# Altium schematic sheet Sheet1.SchDoc
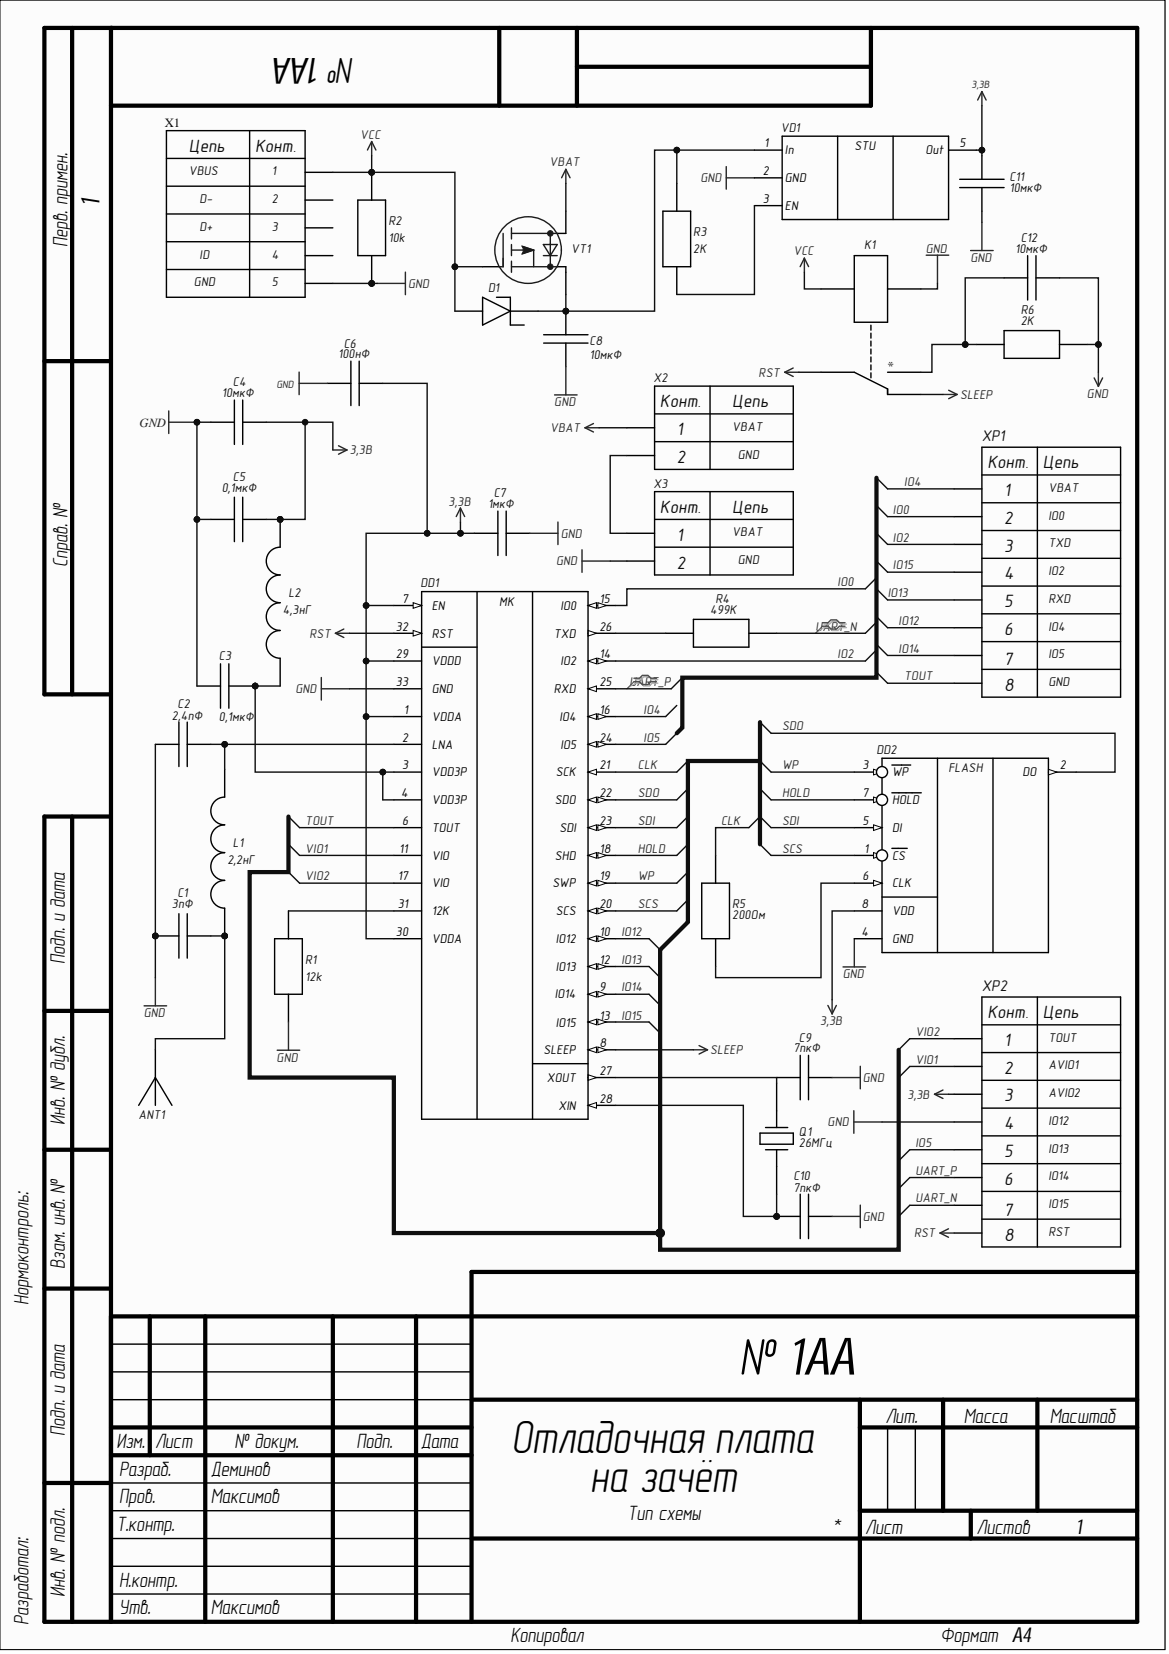
[diagram p0: Sheet1.SchDoc]

## Parts
*  ANT1
C1  3пФ  [0201 C0G 7пФ 25В]
C4  10мкФ  [FB 0603]
C5  0,1мкФ  [RES 0402]
C6  100нФ  [RES 0402]
C8  10мкФ  [FB 0603]
C9  7пкФ  [0201 C0G 7пФ 25В]
C10  7пкФ  [0201 C0G 7пФ 25В]
D1  (no value)  [MBR120]
DD1  esp8266ex  [ESP8266]  (value not drawn)
DD2  M25P16-VMN6TP  [SOIC-8(3.90мм)]  (value not drawn)
K1  gost relay  [RELE]  (value not drawn)
L1  2,2нГ  [FB 0603]
L2  4,3нГ  [RES 0402]
Q1  26МГц  [SMD02520C4]
R1  12k  [RES 0805]
R2  10k  [RES 0805]
R3  2K  [RES 0805]
R4  499К  [RES 0402]
R5  200Ом  [RES 0805]
R6  2K  [RES 0805]
VD1  ap2112  [2112]  (value not drawn)
VT1  (no value)  [SOT23-3]
X1  usb_micro  [USB_mini_B]  (value not drawn)
X2  battery_x2  [Battery_x2AA]  (value not drawn)
X3  battery_x2  [Battery_x2AA]  (value not drawn)
XP1  PLD-8  [PLD-8]  (value not drawn)
XP2  PLD-8  [PLD-8]  (value not drawn)
С2  2,4пФ  [0201 C0G 7пФ 25В]
С3  0,1мкФ  [RES 0402]
С7  1мкФ  [FB 0603]
С11  10мкФ  [FB 0603]
С12  10мкФ  [FB 0603]

## Nets
3,3B: C4.2 C5.1 C6.1 DD1.1(VDDA) DD1.7(EN) DD1.29(VDDD) DD1.30(VDDA) DD2.8(VDD) L2.2 VD1.5(Out) XP2.3 С7.1 С11.2
GND: C1.2 C4.1 C5.2 C6.2 C8.1 C9.1 C10.1 DD1.33(GND) DD2.4(GND) K1.8 R1.2 R2.2 R6.1 VD1.2(GND) X1.5 X3.2 XP2.4 С2.2 С3.2 С7.2 С11.1 С12.1
RST: DD1.32(RST) K1.3 XP2.8
SLEEP: DD1.8(SLEEP) K1.4
VBAT: VT1.2 X2.1
VCC: D1.2 K1.1 R2.1 VT1.1 X1.1
CLK: DD1.21(SCK) R5.1
HOLD: DD1.18(SHD) DD2.7(HOLD)
IO0: DD1.15(IO0) XP1.2
IO2: DD1.14(IO2) XP1.3
IO4: DD1.16(IO4) XP1.1
IO5: DD1.24(IO5) XP2.5
IO12: DD1.10(IO12) XP1.6
IO13: DD1.12(IO13) XP1.5
IO14: DD1.9(IO14) XP1.7
IO15: DD1.13(IO15) XP1.4
SCS: DD1.20(SCS) DD2.1(CS)
SDI: DD1.23(SDI) DD2.5(DI)
SDO: DD1.22(SDO) DD2.2(DO)
TOUT: DD1.6(TOUT) XP1.8
UART_N: R4.2 XP2.7
UART_P: DD1.25(RXD) XP2.6
VIO1: DD1.11(VIO) XP2.2
VIO2: DD1.17(VIO) XP2.1
WP: DD1.19(SWP) DD2.3(WP)
Net*_IN: *.IN C1.1 L1.1
NetC8_2: C8.2 D1.1 R3.2 VD1.1(In) VT1.3
NetC9_2: C9.2 DD1.27(XOUT) Q1.3
NetC10_2: C10.2 DD1.28(XIN) Q1.1
NetDD1_2: DD1.2(LNA) L1.2 С2.1
NetDD1_3: DD1.3(VDD3P) DD1.4(VDD3P) L2.1 С3.1
NetDD1_26: DD1.26(TXD) R4.1
NetDD1_31: DD1.31(12K) R1.1
NetDD2_6: DD2.6(CLK) R5.2
NetK1_3: K1.3 R6.2 С12.2
NetR3_1: R3.1 VD1.3(EN)
NetX2_2: X2.2 X3.1

Not connected: X1 2 3 4

## Library data of the parts
* = ANT GOST: Component Kind: Standard; ComponentLink1Description: New link; ComponentLink1URL: https://www.chipdip.ru/product/1001312; Library Name: my_lib.SchLib
C1 C9 C10 С2 = 0201 cap: Емкость: 7пФ; Напряжение: 25; Component Kind: Standard; Library Name: my_lib.SchLib; Корпус: smd 0201
  C1 С2: Link: https://www.chipdip.ru/product/grm0335c1e7r0bd01d
  C9 C10: Магазин: https://www.chipdip.ru/product/grm0335c1e7r0bd01d
C4 C8 С11 С12 = GRM188R60G106ME47D: Напряжение: 4В; Component Kind: Standard; ComponentLink1URL: https://www.chipdip.ru/product/grm188r60g106me47d; Library Name: my_lib.SchLib
  C4: ComponentLink1Description: New link; Емкость: 10мкФ
  C8: ComponentLink1Description: Магазин; Емкость: 10мкФ
  С11 С12: ComponentLink1Description: New link; Номинал: 10мкФ
C5 C6 С3 = GRM152C80G104KE19D: Емкость: 100nF; Component Kind: Standard; ComponentLink1URL: https://www.chipdip.ru/product/grm152c80g104ke19d; Library Name: my_lib.SchLib
  C5 C6: ComponentLink1Description: New link
  С3: ComponentLink1Description: Магазин
D1 = MBR120: Название: MBR120VLSF; Напряжение: 20V; Ток: 1.0A; Component Kind: Standard; ComponentLink1Description: Магазин; ComponentLink1URL: https://www.chipdip.ru/product0/8002985274?ysclid=lptrg01onk92193897; Library Name: my_lib.SchLib
DD1 = esp8266ex: Напряжение: 3,3В; Component Kind: Standard; ComponentLink1Description: Магазин; ComponentLink1URL: https://www.chipdip.ru/product0/8008541739; Library Name: my_lib.SchLib; Название: ESP8266ex
DD2 = M25P16-VMN6TP: Max freequency: 75 MHz; VCC: 2.7…3.6V; Component Kind: Standard; Library Name: my_lib.SchLib; Link: https://www.chipdip.ru/product/m25p16-vmn6tp-tr
K1 = gost relay: Name: HFD4/5S(R) (IM03GR); Component Kind: Standard; ComponentLink1Description: New link; ComponentLink1URL: https://www.chipdip.ru/product/hfd4-5sr-im03gr; Library Name: my_lib.SchLib
L1 = LQW18AN2N2D: Номинал: 2.2 нГн; Component Kind: Standard; Library Name: my_lib.SchLib; Link: https://www.chipdip.ru/product/lqw18an2n2d
L2 = LQP15MN4N3B02D: Индуктивность: 4.3nH; Component Kind: Standard; ComponentLink1Description: New link; ComponentLink1URL: https://www.chipdip.ru/product/lqp15mn4n3b02d; Library Name: my_lib.SchLib
Q1 = LBY001XRA001: Точность: 10ppm; Частота: 26MHz; Component Kind: Standard; Library Name: my_lib.SchLib; Корпус: SMD02520C4; Магазин: https://www.chipdip.ru/product0/8024602401
R1 = RES 0805: Корпус: 0805; Сопротивлние: 12к; Component Kind: Standard; Library Name: my_lib.SchLib
R2 = RES 0805: Корпус: 0805; Мощность: 0.125Вт; Сопротивление: 10к; Component Kind: Standard; ComponentLink1Description: Магазин; ComponentLink1URL: https://www.chipdip.ru/product/0.125w-0805-10-kom-1; Library Name: my_lib.SchLib
R3 R6 = RES 0805: Корпус: 0805; Мощность: 0.125Вт; Сопротивление: 2к; Component Kind: Standard; ComponentLink1Description: Магазин; ComponentLink1URL: https://www.chipdip.ru/product/0.125w-0805-2-kom-5; Library Name: my_lib.SchLib
R4 = RES 0402: Корпус: 0805; Сопротивлние: 499; Component Kind: Standard; ComponentLink1Description: Магазин; ComponentLink1URL: https://www.chipdip.ru/product0/8002767602?ysclid=lptrsjk7m121054337; Library Name: my_lib.SchLib
R5 = RES 0805: Корпус: 0805; Сопротивление: 200; Component Kind: Standard; ComponentLink1Description: Магазин; ComponentLink1URL: https://www.chipdip.ru/product/0.125w-0805-200-om-1?ysclid=lptrvhj7q8772967812; Library Name: my_lib.SchLib
VD1 = ap2112: Iout: 600; Vdrop: 1300mV; Component Kind: Standard; Library Name: my_lib.SchLib; Name: AP2112
VT1 = SI2325DS-T1-GE3: N-MOSFET; Manufacturer: IXYS; Continuous drain current: 530mA; Database Table Name: MOSFETTransistor$; Gate-to-source voltage: (+-)20B; id: mosfert31; Power dissipation: 750W; Rds: 1,2Ohm; Structure: p-channel; Total gate charge: 7,7nC; V drain-source max: 150B; Component Kind: Standard; ComponentLink1Description: Магазин; ComponentLink1URL: https://www.chipdip.ru/product1/8003370607?ysclid=lptrgqdijn885055873; Library Name: MOP44.DbLib; Part Number: SI2325DS-T1-GE3
X1 = usb_micro: Тип: Разъем usb; Component Kind: Standard; Library Name: my_lib.SchLib
X2 X3 = battery_x2: Name: BH2AAPC-4-12MM; Component Kind: Standard; ComponentLink1Description: New link; ComponentLink1URL: https://www.chipdip.ru/product0/8008602593; Library Name: my_lib.SchLib
XP1 XP2 = PLD-8: PLD connector; Manufacturer: NONAME; Connector type: Вилка на плату; Number of contacts: 8; Component Kind: Standard; Library Name: my_lib.SchLib; Type: Разъем штыревой
С7 = CC0603KRX7R5BB105 1мкФ: Рабочее напряжение: 16В; Component Kind: Standard; ComponentLink1Description: Магазин; ComponentLink1URL: https://www.chipdip.ru/product/grm188r71c105ka12d; Library Name: my_lib.SchLib; Номинал: 1мкФ
